# Altium schematic sheet SH4 - SD CARD.SchDoc
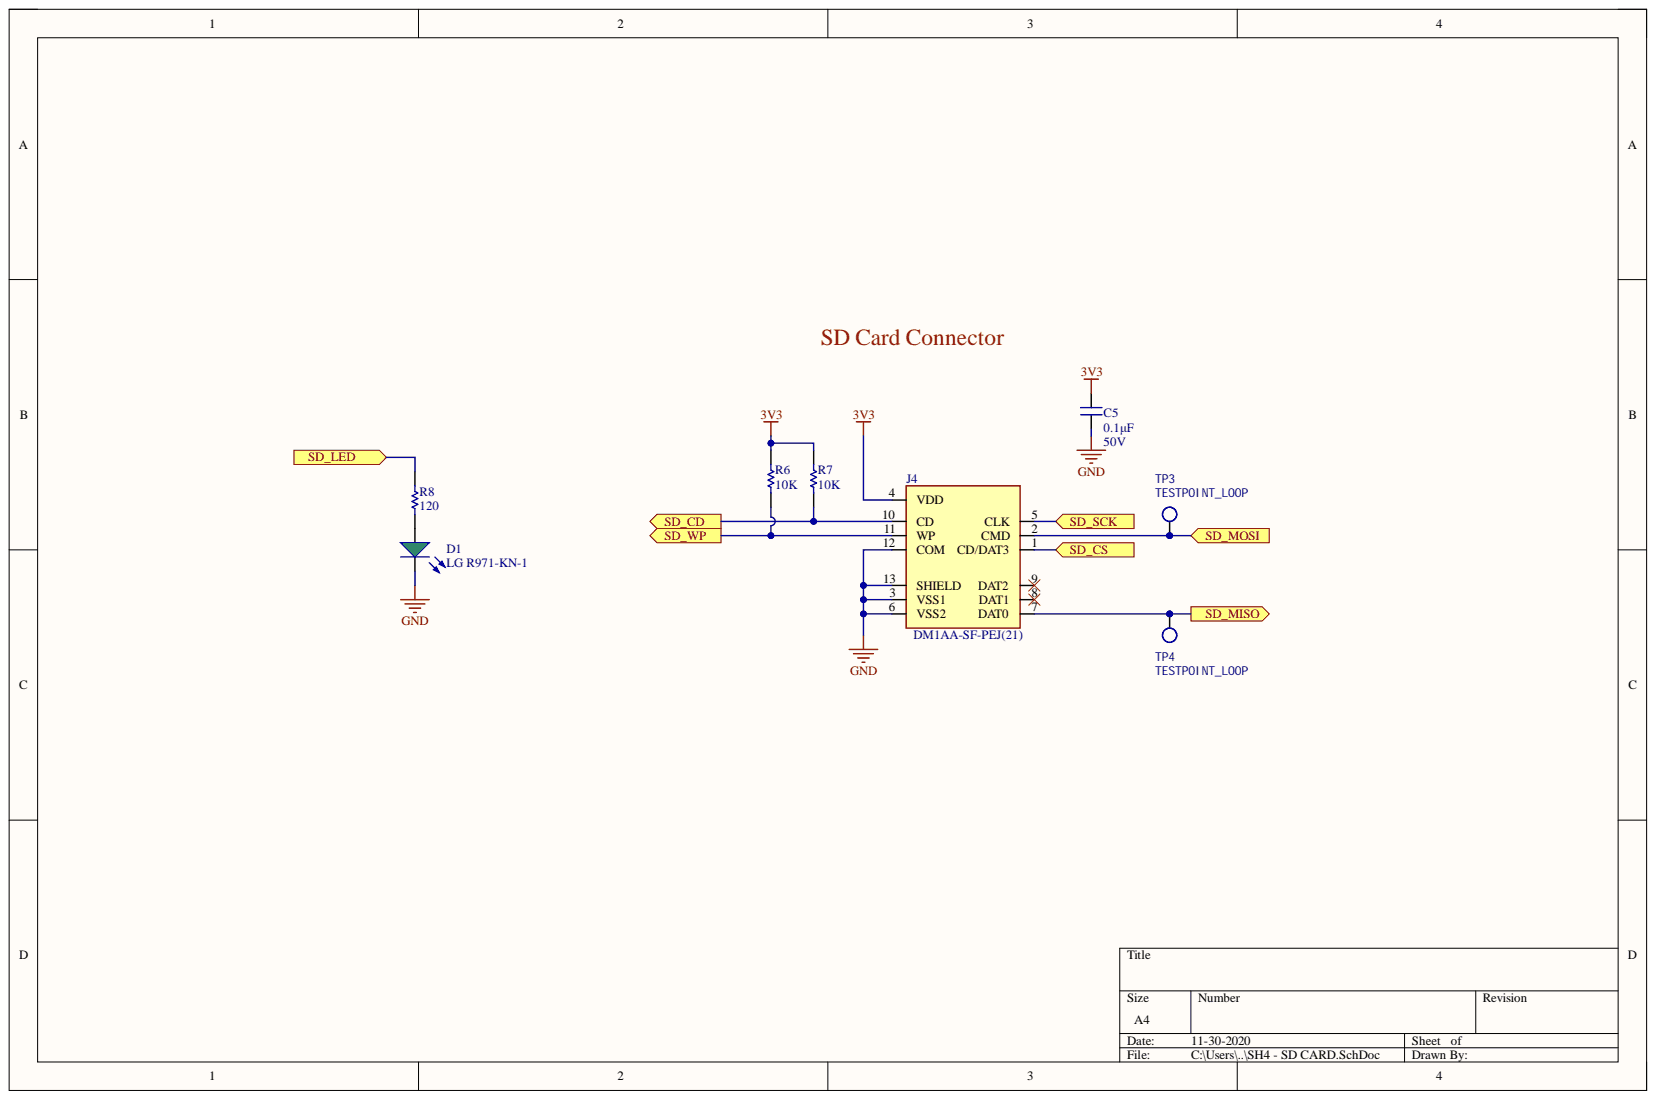
[diagram p0: SH4 - SD CARD.SchDoc]

## Parts
C5  value 0.1μF  Voltage Rating: 50V  [CAP2012]  (hidden comment: CAP_0.1uF_50V_0805)
D1  LG R971-KN-1  [LED_0805_GREEN]
J4  Manufacturer Part Number 1: DM1AA-SF-PEJ(21)  [HRS_DM1AA-SF-PEJ(21)]  (hidden comment: SD_CARD_CON)
R6  value 10K  [RESC0603(1608)_N]  (hidden comment: RES_10K_0603)
R7  value 10K  [RESC0603(1608)_N]  (hidden comment: RES_10K_0603)
R8  value 120  [RESC0603(1608)_N]  (hidden comment: RES_120R_0603)
TP3  TESTPOINT_LOOP  [TESTPOINT_LOOP]
TP4  TESTPOINT_LOOP  [TESTPOINT_LOOP]

## Ports
SD_CD, SD_CS, SD_LED, SD_MISO, SD_MOSI, SD_SCK, SD_WP

## Nets
3V3: C5.2 J4.4(VDD) R6.2 R7.2
GND: C5.1 D1.2 J4.3(VSS1) J4.6(VSS2) J4.12(COM) J4.13(SHIELD)
SD_CD: J4.10(CD) R7.1 ; port SD_CD
SD_CS: J4.1(CD/DAT3) ; port SD_CS
SD_LED: R8.1 ; port SD_LED
SD_MISO: J4.7(DAT0) TP4.1 ; port SD_MISO
SD_MOSI: J4.2(CMD) TP3.1 ; port SD_MOSI
SD_SCK: J4.5(CLK) ; port SD_SCK
SD_WP: J4.11(WP) R6.1 ; port SD_WP
NetD1_1: D1.1 R8.2

Not connected: J4 8(DAT1) 9(DAT2)

## Library data of the parts
C5 = CAP_0.1uF_50V_0805: CAP CER 0.1UF 50V X7R 0805; Manufacturer 1: Murata Electronics; Manufacturer Part Number 1: GCD21BR71H104KA01L; Tolerance: 10%; Case Code (Imperial): 0805; Case Code (Metric): 2012; Component Kind: Standard; Depth: 1.25mm; Dielectric: X7R; Height: 1.25mm; Length: 2mm; Max Operating Temperature: 125°C; Min Operating Temperature: -55°C; Mount: Surface Mount; Package: 0805 (2012 Metric); Ratings: AEC-Q200; Supplier 1: Digi-Key; Supplier Part Number 1: 490-11955-1-ND; Termination: SMD/SMT; Thickness: 0.049inch; Width: 0.049inch; Library Name: UWRT_Schematic_Library_2020.SchLib
D1 = DIO_LED_G: LED GREEN DIFFUSED 0805 SMD; Manufacturer 1: OSRAM; Forward Voltage Drop: 2.2V; Component Kind: Standard; Manufacturer Part Number 1: LG R971-KN-1; Mount: Surface Mount; Package: 0805 (2012 Metric); Supplier 1: Digi-Key; Supplier Part Number 1: 475-1410-1-ND; Library Name: UWRT_Schematic_Library_2020.SchLib
J4 = SD_CARD_CON: CONN SD CARD PUSH-PUSH R/A SMD; Manufacturer 1: Hirose Electric Co Ltd; Card Type: SD; Component Kind: Standard; Mount: Surface Mount; Supplier 1: Digi-Key; Supplier Part Number 1: HR845CT-ND; Library Name: UWRT_Schematic_Library_2020.SchLib
R6 R7 = RES_10K_0603: RES SMD 10K OHM 5% 1/10W 0603; Manufacturer 1: Yageo; Manufacturer Part Number 1: RC0603JR-0710KL; Component Kind: Standard; Dimensions: 0.063" L x 0.031" W (1.60mm x 0.80mm); Mount: Surface Mount; Package: 0603 (1608 Metric); Signal Integrity: Res; Simulation: RESISTOR; Supplier 1: Digi-Key; Supplier Part Number 1: 311-10KGRCT-ND; Tolerance: 5%; Library Name: UWRT_Schematic_Library_2020.SchLib
R8 = RES_120R_0603: RES SMD 120 OHM 5% 1/10W 0603; Manufacturer 1: Yageo; Manufacturer Part Number 1: RC0603JR-07120RL; Component Kind: Standard; Dimensions: 0.063" L x 0.031" W (1.60mm x 0.80mm); Mount: Surface Mount; Package: 0603 (1608 Metric); Signal Integrity: Res; Simulation: RESISTOR; Supplier 1: Digi-Key; Supplier Part Number 1: 311-120GRCT-ND; Tolerance: 5%; Library Name: UWRT_Schematic_Library_2020.SchLib
TP3 TP4 = TESTPOINT_LOOP: PC TEST POINT MINIATURE WHITE; Manufacturer 1: Keystone Electronics; Manufacturer Part Number 1: 5002; Component Kind: Standard; Mount: Through Hole; Supplier 1: Digi-Key; Supplier Part Number 1: 36-5002-ND; Library Name: UWRT_Schematic_Library_2020.SchLib
